# Altium schematic sheet 2364 Adapter.SchDoc
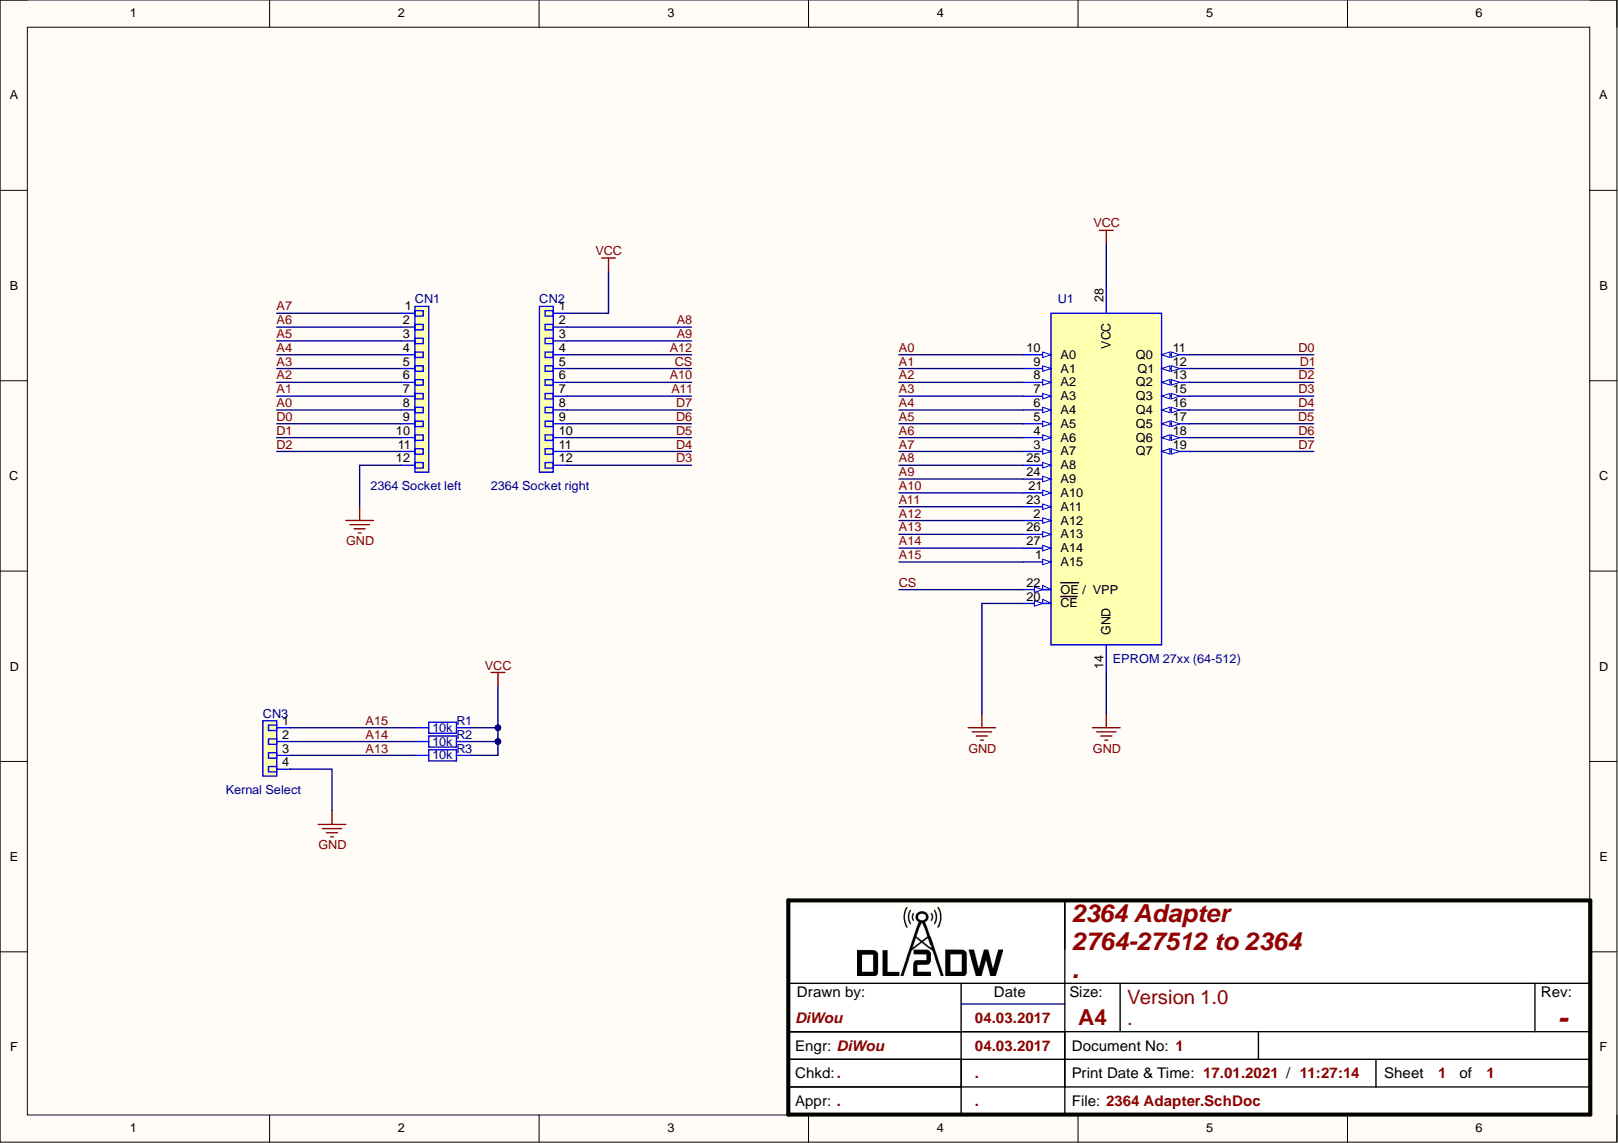
[diagram p0: 2364 Adapter.SchDoc]

## Parts
CN1  2364 Socket left  [Pin Header 01x12 2.54mm Horizontal Male]
CN2  2364 Socket right  [Pin Header 01x12 2.54mm Horizontal Male]
CN3  Kernal Select  [Pin Header 01x04 2.54mm Horizontal Male]
R1  10k  [10 kOhm R Axial DIN0207 L6.3mm D2.5mm P7.62mm Horizontal]
R2  10k  [10 kOhm R Axial DIN0207 L6.3mm D2.5mm P7.62mm Horizontal]
R3  10k  [10 kOhm R Axial DIN0207 L6.3mm D2.5mm P7.62mm Horizontal]
U1  EPROM 27xx (64-512)  [Winbond W27C512-45Z DIP-28 with Socket]

## Nets
GND: CN1.12 CN3.4 U1.14(GND) U1.20(CE)
VCC: CN2.1 R1.2 R2.2 R3.2 U1.28(VCC)
A0: CN1.8 U1.10(A0)
A1: CN1.7 U1.9(A1)
A2: CN1.6 U1.8(A2)
A3: CN1.5 U1.7(A3)
A4: CN1.4 U1.6(A4)
A5: CN1.3 U1.5(A5)
A6: CN1.2 U1.4(A6)
A7: CN1.1 U1.3(A7)
A8: CN2.2 U1.25(A8)
A9: CN2.3 U1.24(A9)
A10: CN2.6 U1.21(A10)
A11: CN2.7 U1.23(A11)
A12: CN2.4 U1.2(A12)
A13: CN3.3 R3.1 U1.26(A13)
A14: CN3.2 R2.1 U1.27(A14)
A15: CN3.1 R1.1 U1.1(A15)
CS: CN2.5 U1.22(OE_/)
D0: CN1.9 U1.11(Q0)
D1: CN1.10 U1.12(Q1)
D2: CN1.11 U1.13(Q2)
D3: CN2.12 U1.15(Q3)
D4: CN2.11 U1.16(Q4)
D5: CN2.10 U1.17(Q5)
D6: CN2.9 U1.18(Q6)
D7: CN2.8 U1.19(Q7)

## Library data of the parts
CN1 CN2 = CMP-Connectors-Male_PIN_Headers-00011-3: CONN HEADER .100 SNGL STR 12POS; Connector Type: Male Pin; Number of Positions: 12; Pitch: 0.1inch; Color: Black; Component Kind: Standard; Contact Mating Length: 0.318inch; Contact Plating: Gold; Library Name: PCB-Tech Cloud; Mount: Through Hole; Number of Rows: 1; Package Quantity: 1; Packaging: Bulk; Termination: Solder
CN3 = CMP-Connectors-Male_PIN_Headers-00003-3: CONN HEADER .100" SNGL STR 4POS; Connector Type: Male Pin; Number of Positions: 4; Pitch: 0.1inch; Color: Black; Component Kind: Standard; Contact Mating Length: 0.318inch; Contact Plating: Gold; Library Name: PCB-Tech Cloud; Mount: Through Hole; Number of Rows: 1; Package Quantity: 1; Packaging: Bulk; Termination: Solder
R1-R3 = CMP-Resistors-Yageo_THT_DIN_0207-00096-1: RES 10K OHM 1/4W 5% AXIAL; Max Power Dissipation: 250mW; Resistance: 10kR; Temperature Coefficient: 500ppm/°C; Case/Package: Axial; Component Kind: Standard; Composition: Carbon Film; Lead Free: Lead Free; Length: 0.248inch; Library Name: PCB-Tech Cloud; Max Operating Temperature: 155°C; Min Operating Temperature: -55°C; Number of Terminations: 2; Package Quantity: 200; Packaging: Bulk; Tolerance: 5%
U1 = CMP-ICs-Memory-00001-2: IC EEPROM 512KBIT 45NS 28DIP; Density: 512Kb; Frequency: 45GHz; Interface: Parallel; Memory Size: 512000B; Operating Supply Voltage: 5V; Access Time: 45ns; Case/Package: DIP; Component Kind: Standard; Lead Free: Lead Free; Library Name: PCB-Tech Cloud; Max Operating Temperature: 70°C; Memory Type: EEPROM; Min Operating Temperature: 0°C; Number of Pins: 28; Package Quantity: 15; Packaging: Rail/Tube; Radiation Hardening: No
